# Altium schematic sheet Display.SchDoc
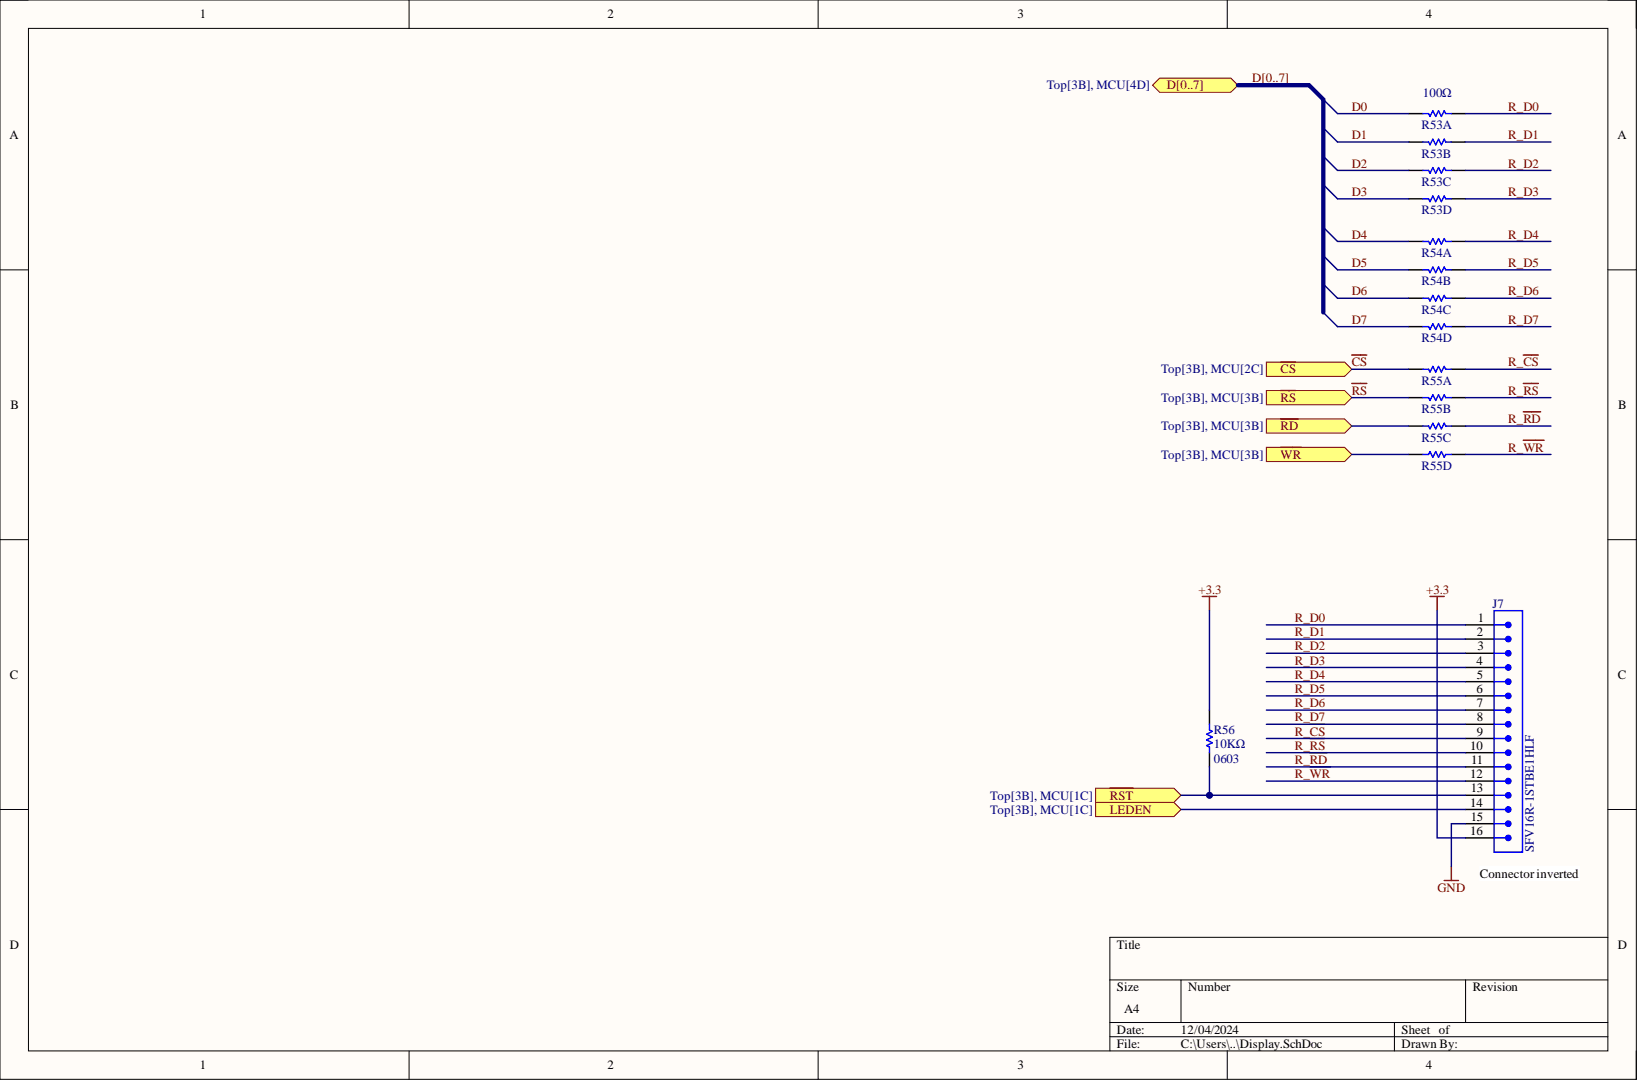
[diagram p0: Display.SchDoc]

## Parts
J7  SFV16R-1STBE1HLF  [FFC16POS]
R53  value 100Ω  [1206]  (hidden comment: YC164-JR-07100RL)  (parts A,B,C,D)
R54  YC164-JR-07100RL  [1206]  (value not drawn)  (parts A,B,C,D)
R55  YC164-JR-07100RL  [1206]  (value not drawn)  (parts A,B,C,D)
R56  value 10KΩ  [0603]  (hidden comment: RMCF0603FG10K0)

## Ports
D0, D1, D2, D3, D4, D5, D6, D7, D[0..7], LEDEN, ~{CS}, ~{RD}, ~{RST}, ~{RS}, ~{WR}

## Nets
+3.3: J7.16 R56.2
GND: J7.15
~{CS}: R55.8 ; port ~{CS}
D0: R53.8 ; port D[0..7]  [also labelled D]
D1: R53.7 ; port D[0..7]  [also labelled D]
D2: R53.6 ; port D[0..7]  [also labelled D]
D3: R53.5 ; port D[0..7]  [also labelled D]
D4: R54.8 ; port D[0..7]  [also labelled D]
D5: R54.7 ; port D[0..7]  [also labelled D]
D6: R54.6 ; port D[0..7]  [also labelled D]
D7: R54.5 ; port D[0..7]  [also labelled D]
LEDEN: J7.14 ; port LEDEN
~{RD}: R55.6 ; port ~{RD}
~{RS}: R55.7 ; port ~{RS}
~{RST}: J7.13 R56.1 ; port ~{RST}
R_~{CS}: J7.9 R55.1
R_D0: J7.1 R53.1
R_D1: J7.2 R53.2
R_D2: J7.3 R53.3
R_D3: J7.4 R53.4
R_D4: J7.5 R54.1
R_D5: J7.6 R54.2
R_D6: J7.7 R54.3
R_D7: J7.8 R54.4
R_~{RD}: J7.11 R55.3
R_~{RS}: J7.10 R55.2
R_~{WR}: J7.12 R55.4
~{WR}: R55.5 ; port ~{WR}

## Library data of the parts
J7 = SFV16R-1STBE1HLF: 16 Position FFC, FPC Connector Contacts, Bottom 0.020" (0.50mm) Surface Mount, Right Angle; Component Kind: Standard; Library Name: Amphenol ICC (FCI).IntLib
R53 = YC164-JR-07100RL: 100 Ohm ±5% 62.5mW Power Per Element Isolated 4 Resistor Network/Array ±200ppm/°C 1206 (3216 Metric), Convex, Long Side Terminals; Case: 1206; Component Kind: Standard; Library Name: YAGEO.IntLib; Power: 62.5mW; Tolerance: ±5%
R54 R55 = YC164-JR-07100RL: 100 Ohm ±5% 62.5mW Power Per Element Isolated 4 Resistor Network/Array ±200ppm/°C 1206 (3216 Metric), Convex, Long Side Terminals; Value: 100Ω; Case: 1206; Component Kind: Standard; Library Name: YAGEO.IntLib; Power: 62.5mW; Tolerance: ±5%
R56 = RMCF0603FG10K0: 10 kOhms ±1% 0.1W, 1/10W Chip Resistor 0603 (1608 Metric) Automotive AEC-Q200 Thick Film; Case: 0603; Component Kind: Standard; Power: 0.1W; Tolerance: 1%; Library Name: Stackpole Electronics Inc.IntLib
